# Altium schematic sheet A6-EINK_TOUCH.SchDoc
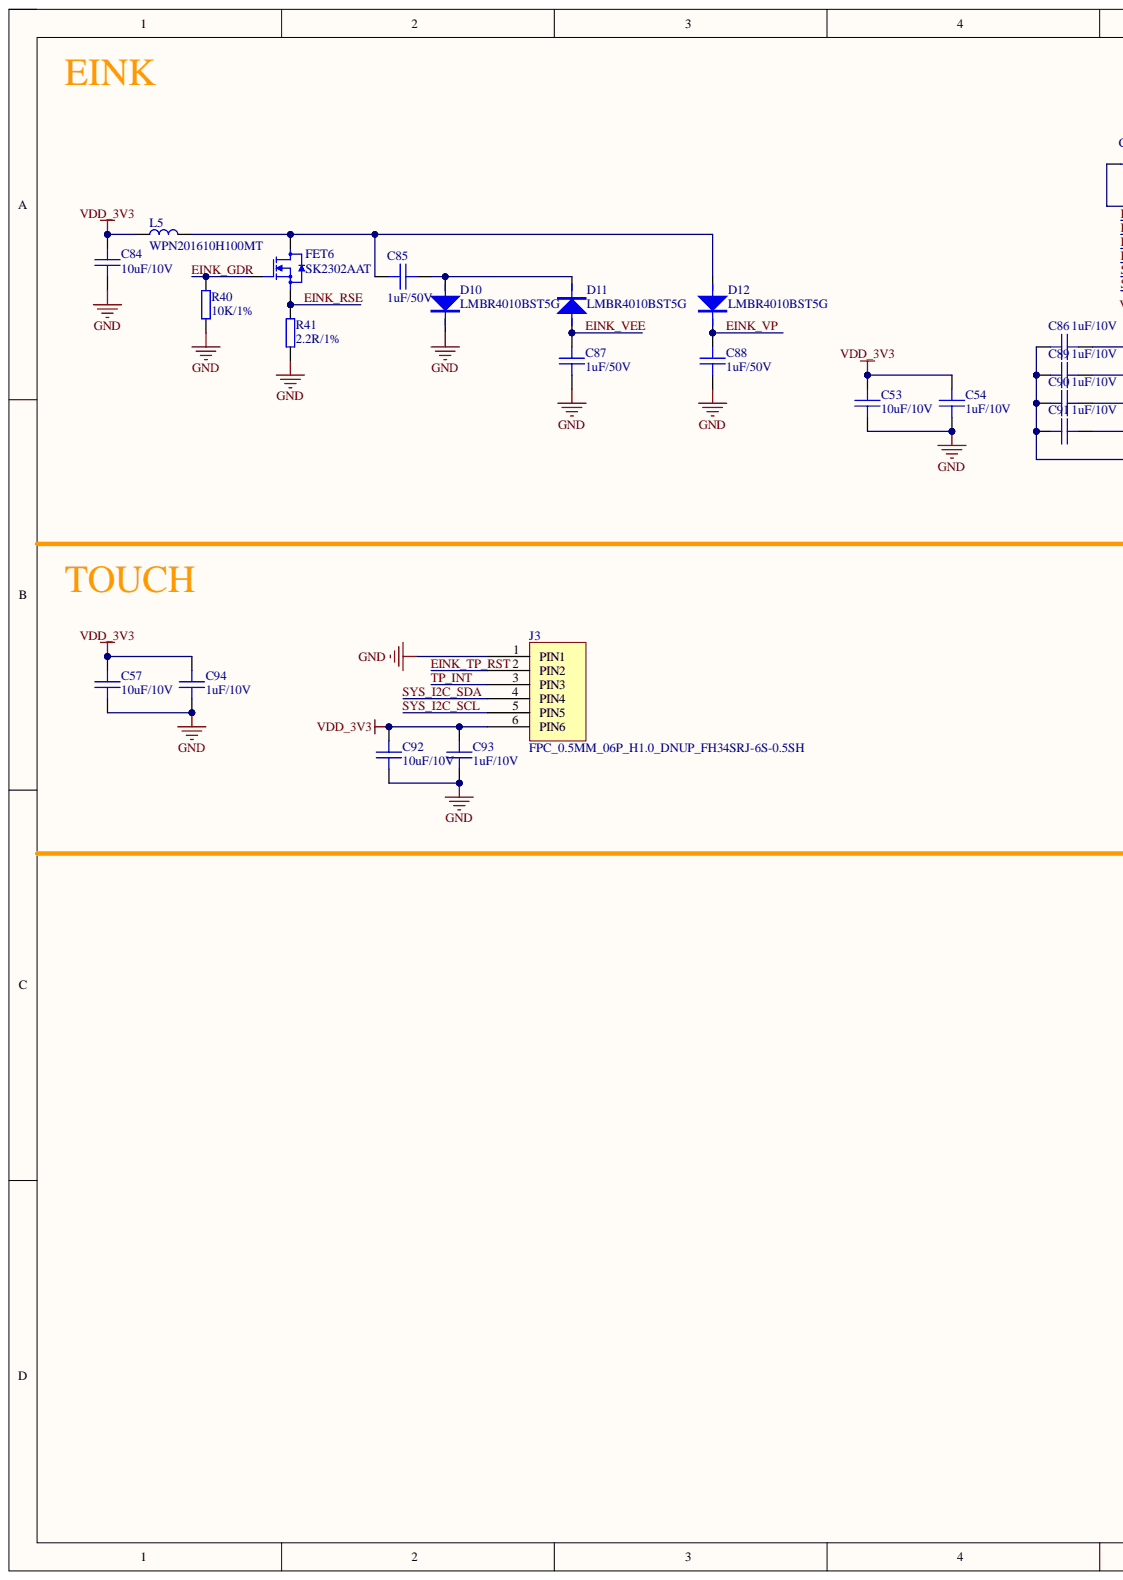
[diagram p0: A6-EINK_TOUCH.SchDoc, left]
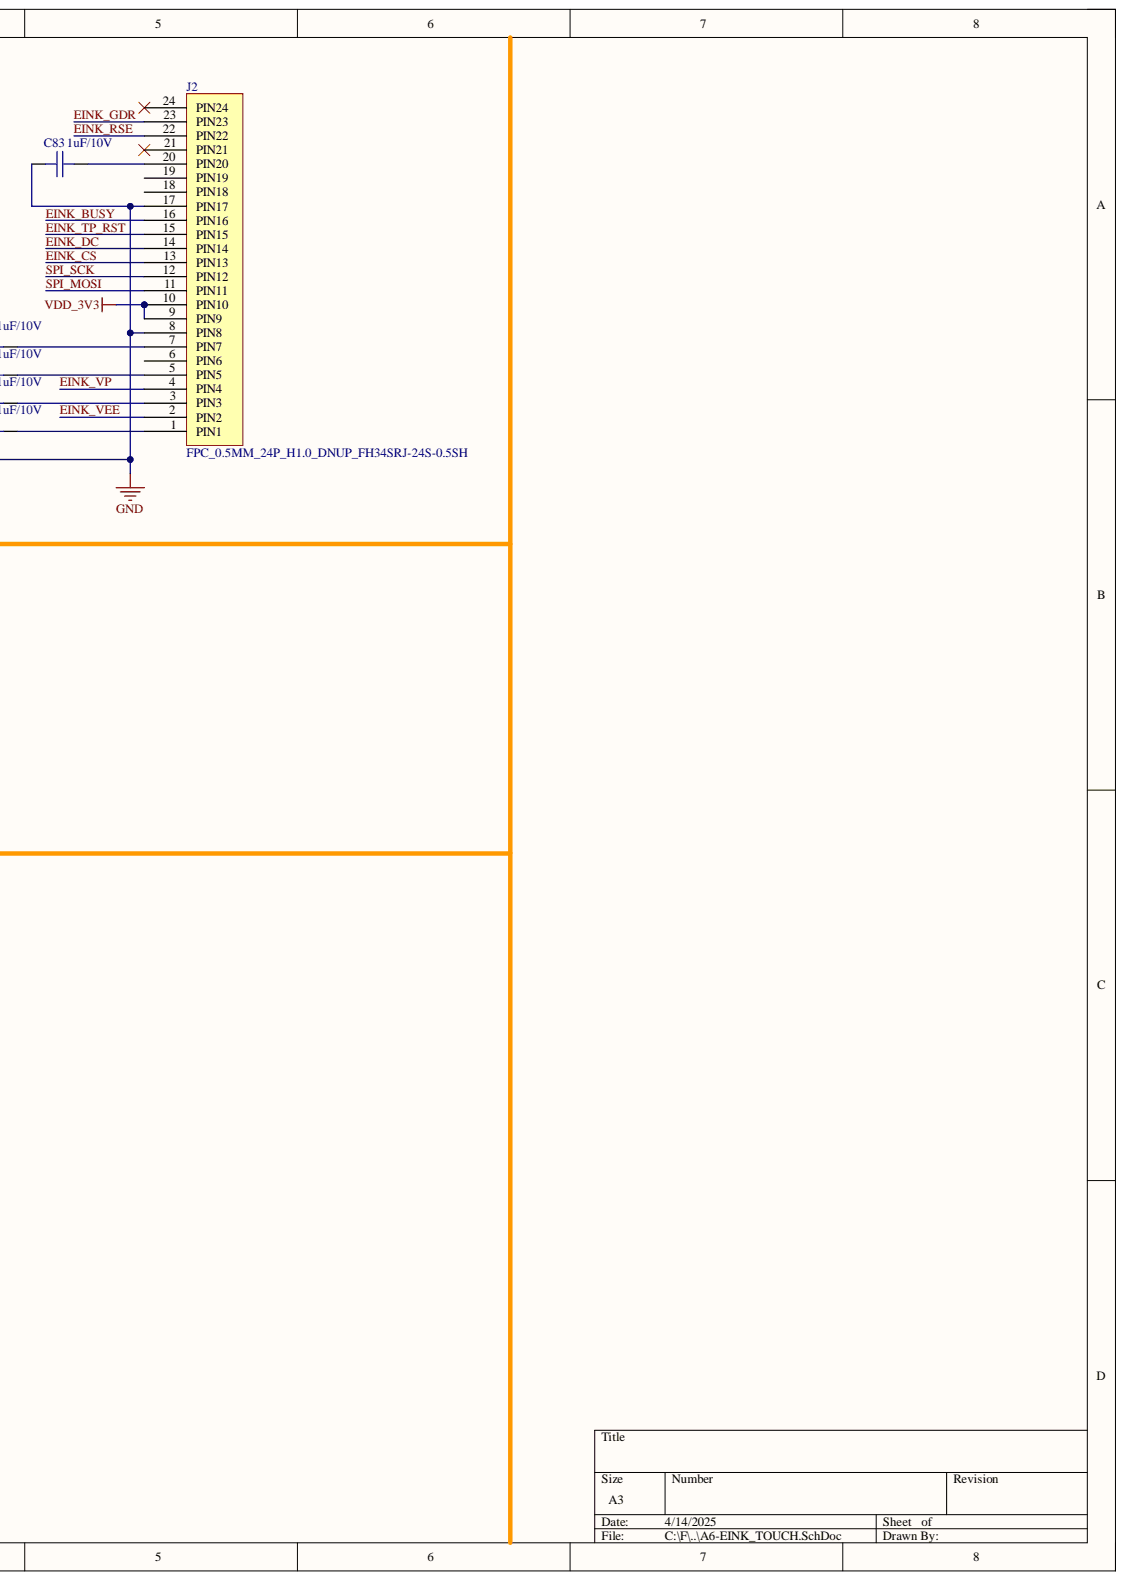
[diagram p1: A6-EINK_TOUCH.SchDoc, right]

## Parts
C53  10uF/10V  [C0402-L-HD]
C54  1uF/10V  [C0201-HD]
C57  10uF/10V  [C0402-L-HD]
C83  1uF/10V  [C0201-HD]
C84  10uF/10V  [C0402-L-HD]
C85  1uF/50V  [C0402-HD]
C86  1uF/10V  [C0201-HD]
C87  1uF/50V  [C0402-HD]
C88  1uF/50V  [C0402-HD]
C89  1uF/10V  [C0201-HD]
C90  1uF/10V  [C0201-HD]
C91  1uF/10V  [C0201-HD]
C92  10uF/10V  [C0402-L-HD]
C93  1uF/10V  [C0201-HD]
C94  1uF/10V  [C0201-HD]
D10  LMBR4010BST5G  [SOD-882-HD]
D11  LMBR4010BST5G  [SOD-882-HD]
D12  LMBR4010BST5G  [SOD-882-HD]
FET6  SK2302AAT  [SOT523]
J2  FPC_0.5MM_24P_H1.0_DNUP_FH34SRJ-24S-0.5SH  [FPC_0.5MM_24P_H1.0_DNUP_FH34SRJ-24S-0.5SH]
J3  FPC_0.5MM_06P_H1.0_DNUP_FH34SRJ-6S-0.5SH  [FPC_0.5MM_06P_H1.0_DNUP_FH34SRJ-6S-0.5SH]
L5  WPN201610H100MT  [MWTC201610-HD]
R40  10K/1%  [R0402-HD]
R41  2.2R/1%  [R0603-HD]

## Nets
GND: C53.1 C54.2 C57.1 C83.1 C84.1 C86.1 C87.1 C88.1 C89.1 C90.1 C91.1 C92.1 C93.1 C94.2 D10.2 J2.8(PIN8) J2.17(PIN17) J3.1(PIN1) R40.2 R41.1
VDD_3V3: C53.2 C54.1 C57.2 C84.2 C92.2 C93.2 C94.1 J2.9(PIN9) J2.10(PIN10) J3.6(PIN6) L5.2
EINK_BUSY: J2.16(PIN16)
EINK_CS: J2.13(PIN13)
EINK_DC: J2.14(PIN14)
EINK_GDR: FET6.1 J2.23(PIN23) R40.1
EINK_RSE: FET6.2 J2.22(PIN22) R41.2
EINK_TP_RST: J2.15(PIN15) J3.2(PIN2)
EINK_VEE: C87.2 D11.1 J2.2(PIN2)
EINK_VP: C88.2 D12.2 J2.4(PIN4)
SPI_MOSI: J2.11(PIN11)
SPI_SCK: J2.12(PIN12)
SYS_I2C_SCL: J3.5(PIN5)
SYS_I2C_SDA: J3.4(PIN4)
TP_INT: J3.3(PIN3)
NetC83_2: C83.2 J2.20(PIN20)
NetC85_1: C85.1 D10.1 D11.2
NetC85_2: C85.2 D12.1 FET6.3 L5.1
NetC86_2: C86.2 J2.7(PIN7)
NetC89_2: C89.2 J2.5(PIN5)
NetC90_2: C90.2 J2.3(PIN3)
NetC91_2: C91.2 J2.1(PIN1)

Not connected: J2 6(PIN6) 18(PIN18) 19(PIN19) 21(PIN21) 24(PIN24)

## Library data of the parts
C53 C54 C57 C83-C94 = SMT_C_0402: Surface mount capacitor 0402; Component Kind: Standard; Library Name: Vowstar_Basic_Device.SchLib
D10-D12 = SMT_DIODE_SOD_523: Surface mount diode SOD-523(0603) Package; Component Kind: Standard; Library Name: Vowstar_Basic_Device.SchLib
FET6 = SI2302-N-Ch-MOSFET: SI2302-N-Ch,3A, 20V.RDS(ON) = 0.082Ω @ VGS = 2.5 V; Component Kind: Standard; Library Name: Vowstar_Basic_Device.SchLib
J2 = FPC0.5-SMT-24P-T: Component Kind: Standard; Description: FPC0.5-SMT-24P-T; Library Name: Vowstar_Connector.SchLib
J3 = FPC0.5-SMT-06P-T: Component Kind: Standard; Description: FPC0.5-SMT-06P-T; Library Name: Vowstar_Connector.SchLib
L5 = SMT_L_0805: Surface mount inductor 0805; Component Kind: Standard; Library Name: Vowstar_Basic_Device.SchLib
R40 R41 = SMT_R_0402: Surface mount resistor 0402; Component Kind: Standard; Library Name: Vowstar_Basic_Device.SchLib
